# Altium schematic sheet CPLD.SchDoc(CPLD)
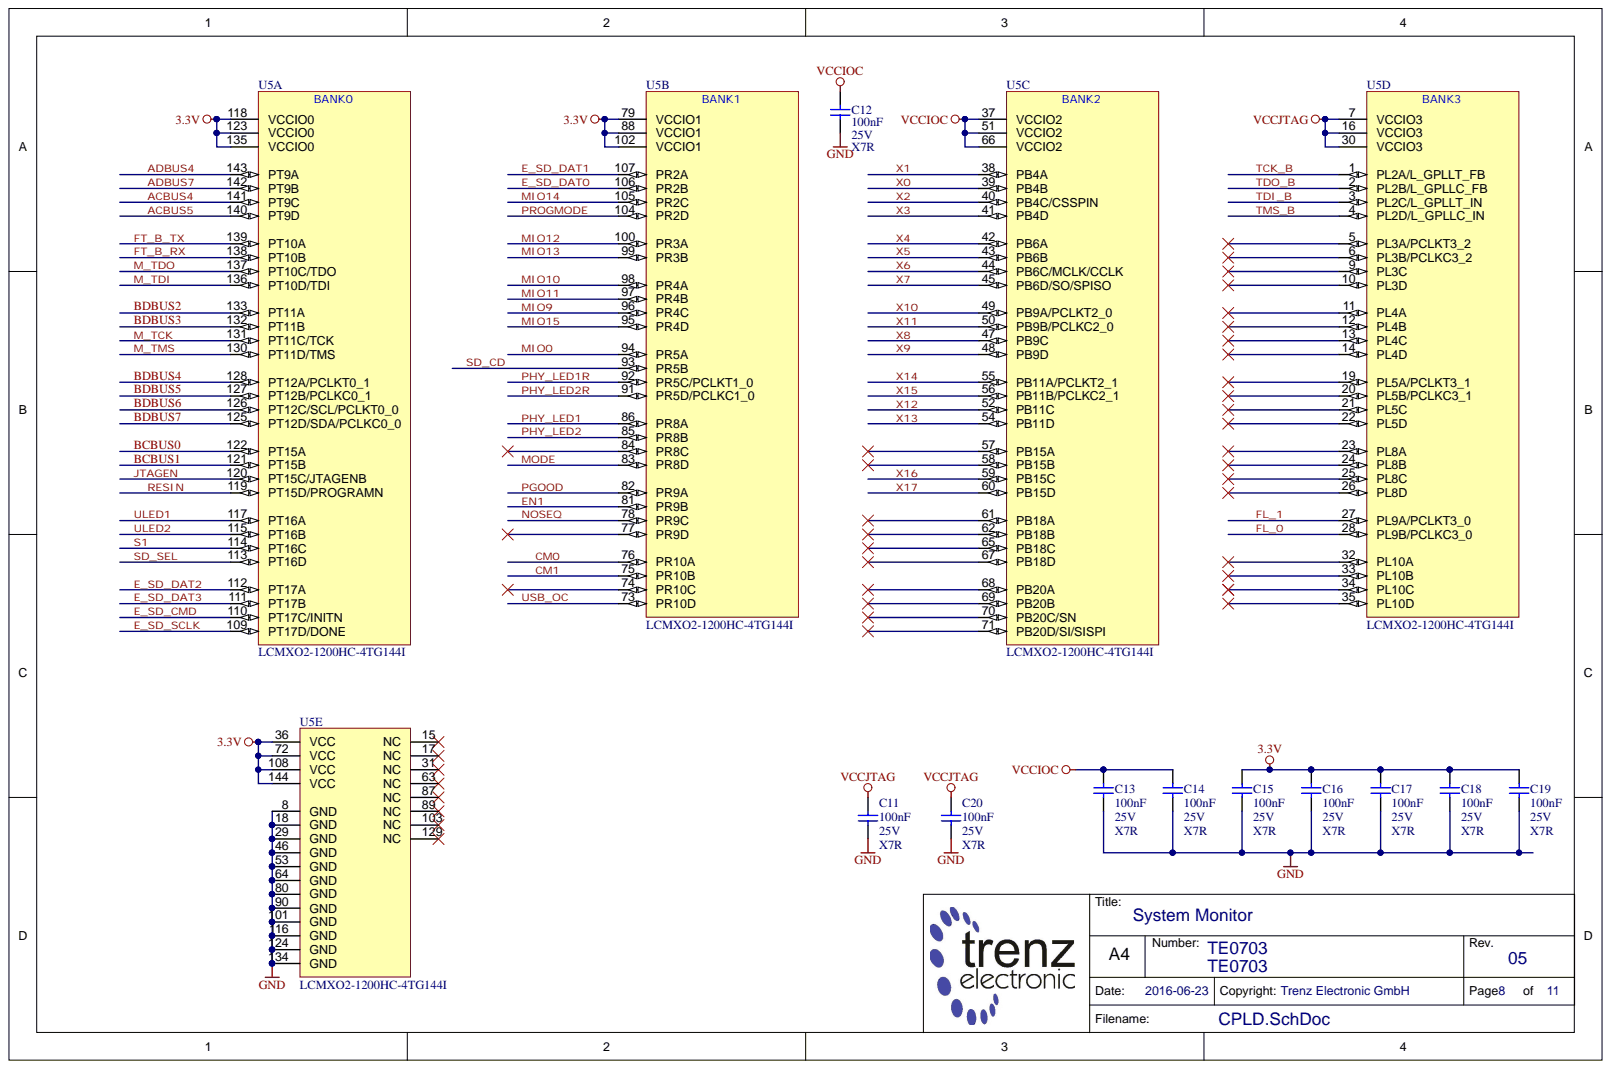
[diagram p0: CPLD.SchDoc]

## Parts
C11  100nF  Technology: X7R  Voltage: 25V  [CAPC1005X55N]
C12  100nF  Technology: X7R  Voltage: 25V  [CAPC1005X55N]
C13  100nF  Technology: X7R  Voltage: 25V  [CAPC1005X55N]
C14  100nF  Technology: X7R  Voltage: 25V  [CAPC1005X55N]
C15  100nF  Technology: X7R  Voltage: 25V  [CAPC1005X55N]
C16  100nF  Technology: X7R  Voltage: 25V  [CAPC1005X55N]
C17  100nF  Technology: X7R  Voltage: 25V  [CAPC1005X55N]
C18  100nF  Technology: X7R  Voltage: 25V  [CAPC1005X55N]
C19  100nF  Technology: X7R  Voltage: 25V  [CAPC1005X55N]
C20  100nF  Technology: X7R  Voltage: 25V  [CAPC1005X55N]
U5  LCMXO2-1200HC-4TG144I  [QFP50P2200X2200X160-144N]  (parts A,B,C,D,E)

## Nets
3.3V: C15.1 C16.1 C17.1 C18.1 C19.1 U5.36(VCC) U5.72(VCC) U5.79(VCCIO1) U5.88(VCCIO1) U5.102(VCCIO1) U5.108(VCC) U5.118(VCCIO0) U5.123(VCCIO0) U5.135(VCCIO0) U5.144(VCC)
GND: C11.2 C12.1 C13.2 C14.2 C15.2 C16.2 C17.2 C18.2 C19.2 C20.2 U5.8(GND) U5.18(GND) U5.29(GND) U5.46(GND) U5.53(GND) U5.64(GND) U5.80(GND) U5.90(GND) U5.101(GND) U5.116(GND) U5.124(GND) U5.134(GND)
VCCIOC: C12.2 C13.1 C14.1 U5.37(VCCIO2) U5.51(VCCIO2) U5.66(VCCIO2)
VCCJTAG: C11.1 C20.1 U5.7(VCCIO3) U5.16(VCCIO3) U5.30(VCCIO3)
ACBUS4: U5.141(PT9C)
ACBUS5: U5.140(PT9D)
ADBUS4: U5.143(PT9A)
ADBUS7: U5.142(PT9B)
BCBUS0: U5.122(PT15A)
BCBUS1: U5.121(PT15B)
BDBUS2: U5.133(PT11A)
BDBUS3: U5.132(PT11B)
BDBUS4: U5.128(PT12A/PCLKT0_1)
BDBUS5: U5.127(PT12B/PCLKC0_1)
BDBUS6: U5.126(PT12C/SCL/PCLKT0_0)
BDBUS7: U5.125(PT12D/SDA/PCLKC0_0)
CM0: U5.76(PR10A)
CM1: U5.75(PR10B)
E_SD_CMD: U5.110(PT17C/INITN)
E_SD_DAT0: U5.106(PR2B)
E_SD_DAT1: U5.107(PR2A)
E_SD_DAT2: U5.112(PT17A)
E_SD_DAT3: U5.111(PT17B)
E_SD_SCLK: U5.109(PT17D/DONE)
EN1: U5.81(PR9B)
FL_0: U5.28(PL9B/PCLKC3_0)
FL_1: U5.27(PL9A/PCLKT3_0)
FT_B_RX: U5.138(PT10B)
FT_B_TX: U5.139(PT10A)
JTAGEN: U5.120(PT15C/JTAGENB)
M_TCK: U5.131(PT11C/TCK)
M_TDI: U5.136(PT10D/TDI)
M_TDO: U5.137(PT10C/TDO)
M_TMS: U5.130(PT11D/TMS)
MIO0: U5.94(PR5A)
MIO9: U5.96(PR4C)
MIO10: U5.98(PR4A)
MIO11: U5.97(PR4B)
MIO12: U5.100(PR3A)
MIO13: U5.99(PR3B)
MIO14: U5.105(PR2C)
MIO15: U5.95(PR4D)
MODE: U5.83(PR8D)
NOSEQ: U5.78(PR9C)
PGOOD: U5.82(PR9A)
PHY_LED1: U5.86(PR8A)
PHY_LED1R: U5.92(PR5C/PCLKT1_0)
PHY_LED2: U5.85(PR8B)
PHY_LED2R: U5.91(PR5D/PCLKC1_0)
PROGMODE: U5.104(PR2D)
RESIN: U5.119(PT15D/PROGRAMN)
S1: U5.114(PT16C)
SD_CD: U5.93(PR5B)
SD_SEL: U5.113(PT16D)
TCK_B: U5.1(PL2A/L_GPLLT_FB)
TDI_B: U5.3(PL2C/L_GPLLT_IN)
TDO_B: U5.2(PL2B/L_GPLLC_FB)
TMS_B: U5.4(PL2D/L_GPLLC_IN)
ULED1: U5.117(PT16A)
ULED2: U5.115(PT16B)
USB_OC: U5.73(PR10D)
X0: U5.39(PB4B)
X1: U5.38(PB4A)
X2: U5.40(PB4C/CSSPIN)
X3: U5.41(PB4D)
X4: U5.42(PB6A)
X5: U5.43(PB6B)
X6: U5.44(PB6C/MCLK/CCLK)
X7: U5.45(PB6D/SO/SPISO)
X8: U5.47(PB9C)
X9: U5.48(PB9D)
X10: U5.49(PB9A/PCLKT2_0)
X11: U5.50(PB9B/PCLKC2_0)
X12: U5.52(PB11C)
X13: U5.54(PB11D)
X14: U5.55(PB11A/PCLKT2_1)
X15: U5.56(PB11B/PCLKC2_1)
X16: U5.59(PB15C)
X17: U5.60(PB15D)

Not connected: U5 5(PL3A/PCLKT3_2) 6(PL3B/PCLKC3_2) 9(PL3C) 10(PL3D) 11(PL4A) 12(PL4B) 13(PL4C) 14(PL4D) 15(NC) 17(NC) 19(PL5A/PCLKT3_1) 20(PL5B/PCLKC3_1) 21(PL5C) 22(PL5D) 23(PL8A) 24(PL8B) 25(PL8C) 26(PL8D) 31(NC) 32(PL10A) 33(PL10B) 34(PL10C) 35(PL10D) 57(PB15A) 58(PB15B) 61(PB18A) 62(PB18B) 63(NC) 65(PB18C) 67(PB18D) 68(PB20A) 69(PB20B) 70(PB20C/SN) 71(PB20D/SI/SISPI) 74(PR10C) 77(PR9D) 84(PR8C) 87(NC) 89(NC) 103(NC) 129(NC)

## Library data of the parts
C11-C20 = 22472: KON 100nF 25V X5R SMD; Database Table Name: C_1005_0402; Mixed: SMT; Preferred: Yes; Value: 100n; AN: 22472; Case: 1005 (0402); Component Kind: Standard; Library Name: TE.DbLib
U5 = 25915: Database Table Name: PROG_LOGIC; Mixed: SMT; Trenz-Stock: Yes; AN: 25915; Case: TQFP144; Component Kind: Standard; Description: LCMXO2-1200HC-4TG144I; H-ART: LCMXO2-1200HC-4TG144I; Library Name: TE.DbLib; HelpURL: B:\AD\adlib\datasheet\MachXO2
